# Altium schematic sheet Top.SchDoc
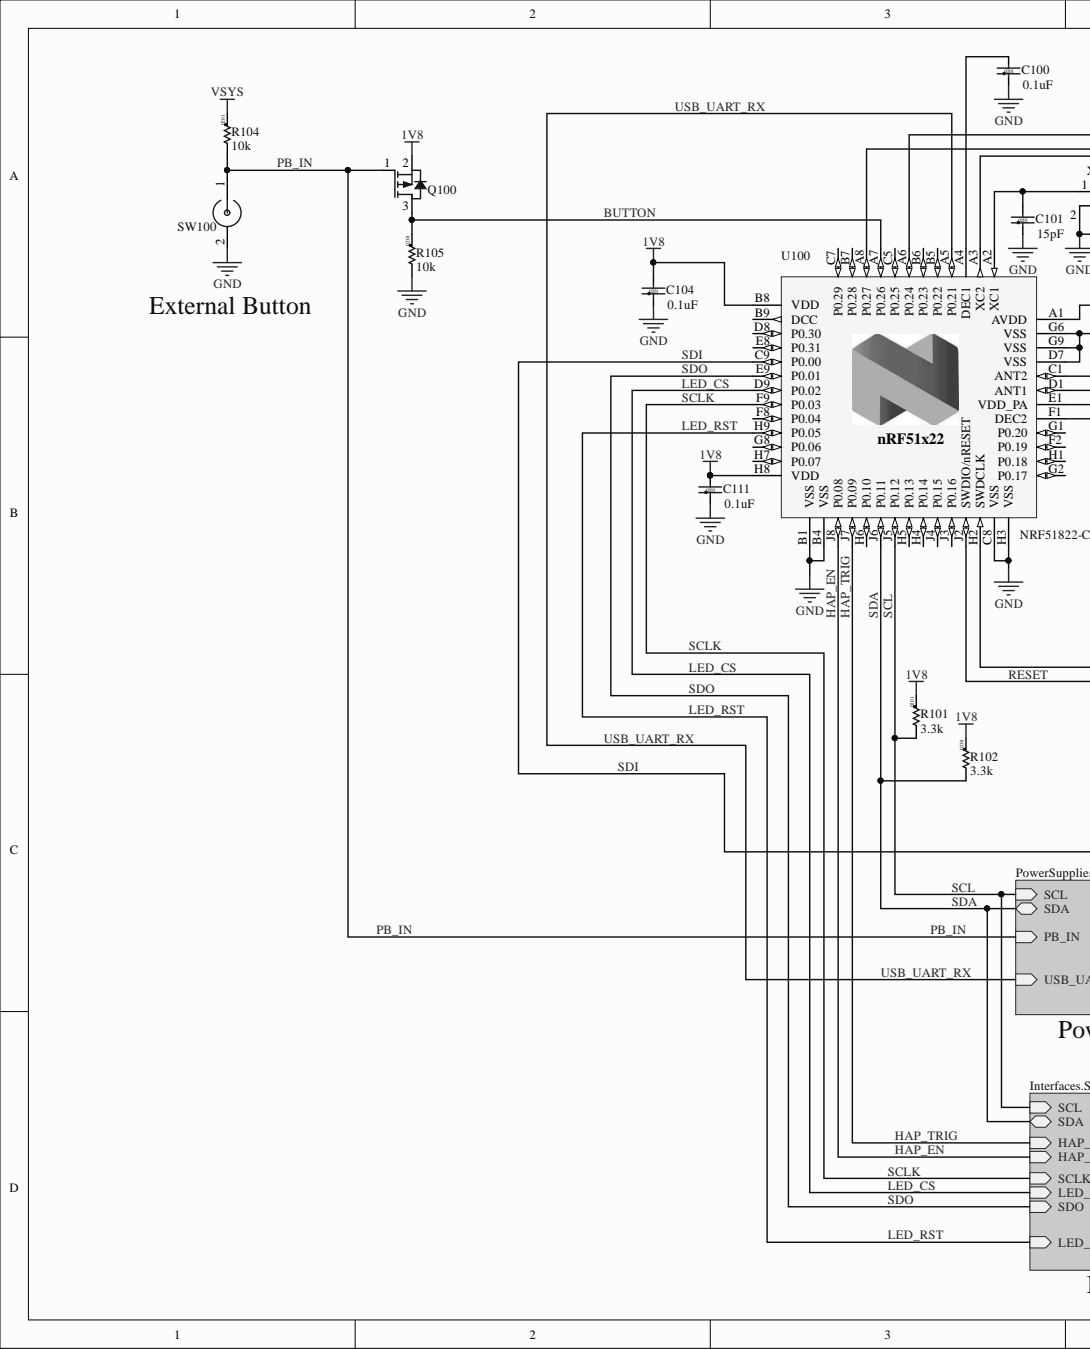
[diagram p0: Top.SchDoc, left]
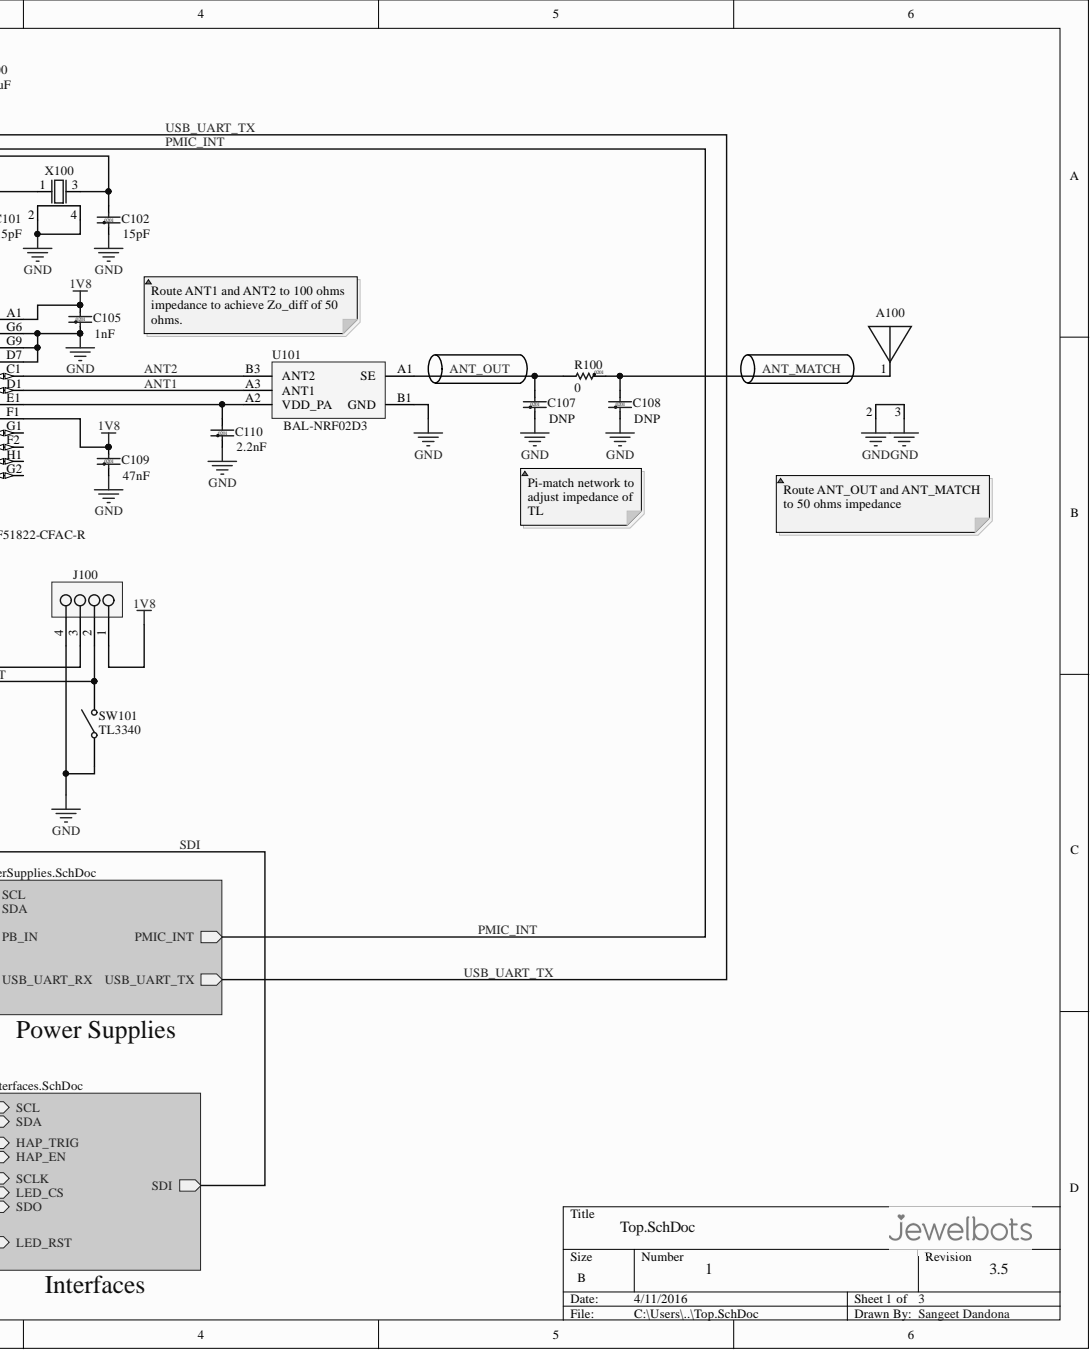
[diagram p1: Top.SchDoc, right]

## Parts
A100  Part Number  [2450AT07A0100]  (value not drawn)
C100  value 0.1uF  Size: 0201  [Cap 0201 HD]  (hidden comment: Part Number0.1uFDNP)
C101  value 15pF  Size: 0201  [Cap 0201 HD]  (hidden comment: Part Number15pFDNP)
C102  value 15pF  Size: 0201  [Cap 0201 HD]  (hidden comment: Part Number15pFDNP)
C104  value 0.1uF  Size: 0201  [Cap 0201 HD]  (hidden comment: Part Number0.1uFDNP)
C105  value 1nF  Size: 0201  [Cap 0201 HD]  (hidden comment: Part Number1nFDNP)
C107  value DNP  MFPN: DNP  Size: 0201  [Cap 0201 HD]  (hidden comment: Part NumberDNPDNP)
C108  value DNP  MFPN: DNP  Size: 0201  [Cap 0201 HD]  (hidden comment: Part NumberDNPDNP)
C109  value 47nF  Size: 0201  [Cap 0201 HD]  (hidden comment: Part Number47nFDNP)
C110  value 2.2nF  Size: 0201  [Cap 0201 HD]  (hidden comment: Part Number2.2nFDNP)
C111  value 0.1uF  Size: 0201  [Cap 0201 HD]  (hidden comment: Part Number0.1uFDNP)
J100  Part NumberDNP  [TP10R_1.27P-4Pin]  (value not drawn)
Q100  Part Number  [SOT-723]  (value not drawn)
R100  value 0  Size: 0201  [RES 0201 HD]  (hidden comment: Part Number0DNP)
R101  value 3.3k  Size: 0201  [RES 0201 HD]  (hidden comment: Part Number3.3kDNP)
R102  value 3.3k  Size: 0201  [RES 0201 HD]  (hidden comment: Part Number3.3kDNP)
R104  value 10k  Size: 0201  [RES 0201 HD]  (hidden comment: Part Number10kDNP)
R105  value 10k  Size: 0201  [RES 0201 HD]  (hidden comment: Part Number10kDNP)
SW100  Part Number  [GX05170]  (value not drawn)
SW101  TL3340  [TL3340]
U100  MFPN: NRF51822-CFAC-R  [CFAC_WLCSP]  (hidden comment: Part NumberDNP)
U101  Part Number  [BGA0.4P2x3-5Pin]  (value not drawn)
X100  Part NumberDNP  [XTAL_ABM11-16]  (value not drawn)

## Nets
1V8: C104.1 C105.1 C109.1 C111.1 J100.1 Q100.2 R101.2 R102.2 U100.A1(AVDD) U100.B8(VDD) U100.F1(DEC2) U100.H8(VDD)
GND: A100.2 A100.3 C100.2 C101.2 C102.2 C104.2 C105.2 C107.2 C108.2 C109.2 C110.2 C111.2 J100.4 R105.1 SW100.2 SW101.1 U100.B1(VSS) U100.B4(VSS) U100.C8(VSS) U100.D7(VSS) U100.G3 U100.G4 U100.G6(VSS) U100.G9(VSS) U100.H3(VSS) U101.B1(GND) X100.2 X100.4
VSYS: R104.2
~{LED_CS}: U100.D9(P0.02)
~{LED_RST}: U100.H9(P0.05)
~{PB_IN}: Q100.1 R104.1 SW100.1
~{RESET}: J100.2 SW101.2 U100.J2(SWDIO/nRESET)
ANT1: U100.D1(ANT1) U101.A3(ANT1)
ANT2: U100.C1(ANT2) U101.B3(ANT2)
ANT_MATCH: A100.1 C108.1 R100.2
ANT_OUT: C107.1 R100.1 U101.A1(SE)
BUTTON: Q100.3 R105.2 U100.A7(P0.26)
HAP_EN: U100.J8(P0.08)
HAP_TRIG: U100.J7(P0.09)
PMIC_INT: U100.A8(P0.27)
SCL: R101.1 U100.J5(P0.12)
SCLK: U100.F9(P0.03)
SDA: R102.1 U100.J6(P0.11)
SDI: U100.C9(P0.00)
SDO: U100.E9(P0.01)
USB_UART_RX: U100.A5(P0.21)
USB_UART_TX: U100.A6(P0.24)
NetC100_1: C100.1 U100.A4(DEC1)
NetC101_1: C101.1 U100.A2(XC1) X100.1
NetC102_1: C102.1 U100.A3(XC2) X100.3
NetC110_1: C110.1 U100.E1(VDD_PA) U101.A2(VDD_PA)
NetJ100_3: J100.3 U100.H2(SWDCLK)
NetU100_B5: U100.B5(P0.22)
NetU100_B6: U100.B6(P0.23)
NetU100_B7: U100.B7(P0.28)
NetU100_B9: U100.B9(DCC)
NetU100_C5: U100.C5(P0.25)
NetU100_C7: U100.C7(P0.29)
NetU100_D8: U100.D8(P0.30)
NetU100_E8: U100.E8(P0.31)
NetU100_F2: U100.F2(P0.19)
NetU100_F8: U100.F8(P0.04)
NetU100_G1: U100.G1(P0.20)
NetU100_G2: U100.G2(P0.17)
NetU100_G8: U100.G8(P0.06)
NetU100_H1: U100.H1(P0.18)
NetU100_H4: U100.H4(P0.14)
NetU100_H5: U100.H5(P0.13)
NetU100_H6: U100.H6(P0.10)
NetU100_H7: U100.H7(P0.07)
NetU100_J3: U100.J3(P0.16)
NetU100_J4: U100.J4(P0.15)

## Library data of the parts
A100 = 2450AT07A0100: ANTENNA SMD EIA 0402 2.4GHZ; Database Table Name: RF; MF: Johanson Technology Inc.; MFPN: 2450AT07A0100T; Component Kind: Standard; Cost: 0.36562; Height: 0.37mm; Size: 1mmx0.5mm; Library Name: Rigado_AltiumLib.DbLib
C100 C104 C111 = Cap 0201 0.1uF 6.3V: CAP CER 0.1UF 6.3V 10% X5R 0201; Database Table Name: Capacitors; MF: Murata Electronics North America; MFPN: GRM033R60J104KE19D; Component Kind: Standard; Cost: 0.00650; Signal Integrity: Cap Semi; Simulation: CAP; Library Name: Rigado_AltiumLib.DbLib
C101 C102 = Cap 0201 11pF 25V: CAP CER 15PF 25V NP0 0201; Database Table Name: Capacitors; MF: Murata Electronics North America; MFPN: GRM0335C1E150FA01D; Component Kind: Standard; Cost: 0.00900; Signal Integrity: Cap Semi; Simulation: CAP; Library Name: Rigado_AltiumLib.DbLib
C105 = Cap 0201 1nF 25V: CAP CER 1000PF 25V 10% X7R 0201; Database Table Name: Capacitors; MF: Murata Electronics North America; MFPN: GRM033R71E102KA01D; Component Kind: Standard; Cost: 0.00500; Signal Integrity: Cap Semi; Simulation: CAP; Library Name: Rigado_AltiumLib.DbLib
C107 C108 = Cap 0201 Generic: Capacitor, Ceramic 0201, DNP; Database Table Name: Capacitors; Component Kind: Standard; Cost: 0; MF: N/A; Signal Integrity: Cap Semi; Simulation: CAP; Library Name: Rigado_AltiumLib.DbLib
C109 = Cap 0201 47nF 10V: CAP CER 0.047UF 10V 10% X5R 0201; Database Table Name: Capacitors; MF: Taiyo Yuden; MFPN: LMK063BJ473KP-F; Component Kind: Standard; Cost: 0.02325; Signal Integrity: Cap Semi; Simulation: CAP; Library Name: Rigado_AltiumLib.DbLib
C110 = Cap 0201 2.2nF 16V: CAP CER 2200PF 16V 10% X7R 0201; Database Table Name: Capacitors; MF: Murata Electronics North America; MFPN: GRM033R71C222KA88D; Component Kind: Standard; Cost: 0.00525; Signal Integrity: Cap Semi; Simulation: CAP; Library Name: Rigado_AltiumLib.DbLib
J100 = SWD_Pogo_TP: Test points for 4-wire Serial Wire Debugger header Pogo Pin harness, DNP; Database Table Name: Testpoints & Pads; Component Kind: Standard; Cost: 0; MF: N/A; MFPN: DNP; Size: 4.8mm x 1mm; Library Name: Rigado_AltiumLib.DbLib
Q100 = MOSFET-P: MOSFET P-CH 20V 0.1A VMT3; MF: Rohm Semiconductor; MFPN: RZM001P02T2L; Component Kind: Standard; Cost: 0.03792; Package: SOT-723; Size: 1.2mm x 0.8mm; Type: MOSFET-P; Library Name: JWB-001_Main.SCHLIB
R100 = Res 0201 0R Jumper: RES SMD 0.0OHM JUMPER 1/20W 0201; Database Table Name: Resistors; MF: Samsung Electro-Mechanics America, Inc.; MFPN: RC0603J000CS; Component Kind: Standard; Cost: 0.00787; Signal Integrity: Res; Simulation: RESISTOR; Library Name: Rigado_AltiumLib.DbLib
R101 R102 = Res 0201 3.3k 5%: RES SMD 3.3K OHM 5% 1/20W 0201; Database Table Name: Resistors; MF: Samsung Electro-Mechanics America, Inc.; MFPN: RC0603J332CS; Component Kind: Standard; Cost: 0.00583; Signal Integrity: Res; Simulation: RESISTOR; Library Name: Rigado_AltiumLib.DbLib
R104 R105 = Res 0201 10k 5%: RES SMD 10K OHM 5% 1/20W 0201; Database Table Name: Resistors; MF: Rohm Semiconductor; MFPN: MCR006YRTJ103; Component Kind: Standard; Cost: 0.00594; Signal Integrity: Res; Simulation: RESISTOR; Library Name: Rigado_AltiumLib.DbLib
SW100 = GX05170: Snap Dome, high activation force, 5.3mm dia; Database Table Name: Buttons & Switches; MF: Snaptron; Component Kind: Standard; Cost: 0; MFPN: GX05170; Size: 5.3mm dia; Library Name: Rigado_AltiumLib.DbLib
SW101 = TL3340: SWITCH TACTILE SPST-NO 0.05A 12V; MF: E-Switch; MFPN: TL3340AF160QG; Component Kind: Standard; Cost: 0.30030; Size: 4.00mm x 3.30mm; Library Name: JWB-001_Main.SCHLIB
U100 = nRF51822-CFAC: IC SOC 2.4GHZ MULTIPROTO 62WLCS; Database Table Name: Controllers; MF: Nordic Semiconductor ASA; Component Kind: Standard; Cost: 2.52225; Library Name: Rigado_AltiumLib.DbLib
U101 = BAL-NRF02D3: IC BALUN FOR NRF51822 WLCSP; Database Table Name: RF; MF: STMicroelectronics; Component Kind: Standard; Cost: 0.20300; MFPN: BAL-NRF02D3; Size: 1.435mmx.905mm; Library Name: Rigado_AltiumLib.DbLib
X100 = XTAL_ABM11-16: CRYSTAL 16.0000MHZ 10PF SMD; MF: Abracon LLC; MFPN: ABM11-16.000MHZ-B7G-T; Component Kind: Standard; Cost: 0.75600; Size: 2.0mm x 1.6mm; Library Name: JWB-001_Main.SCHLIB
